# Altium schematic sheet main_scheme.SchDoc(main_scheme)
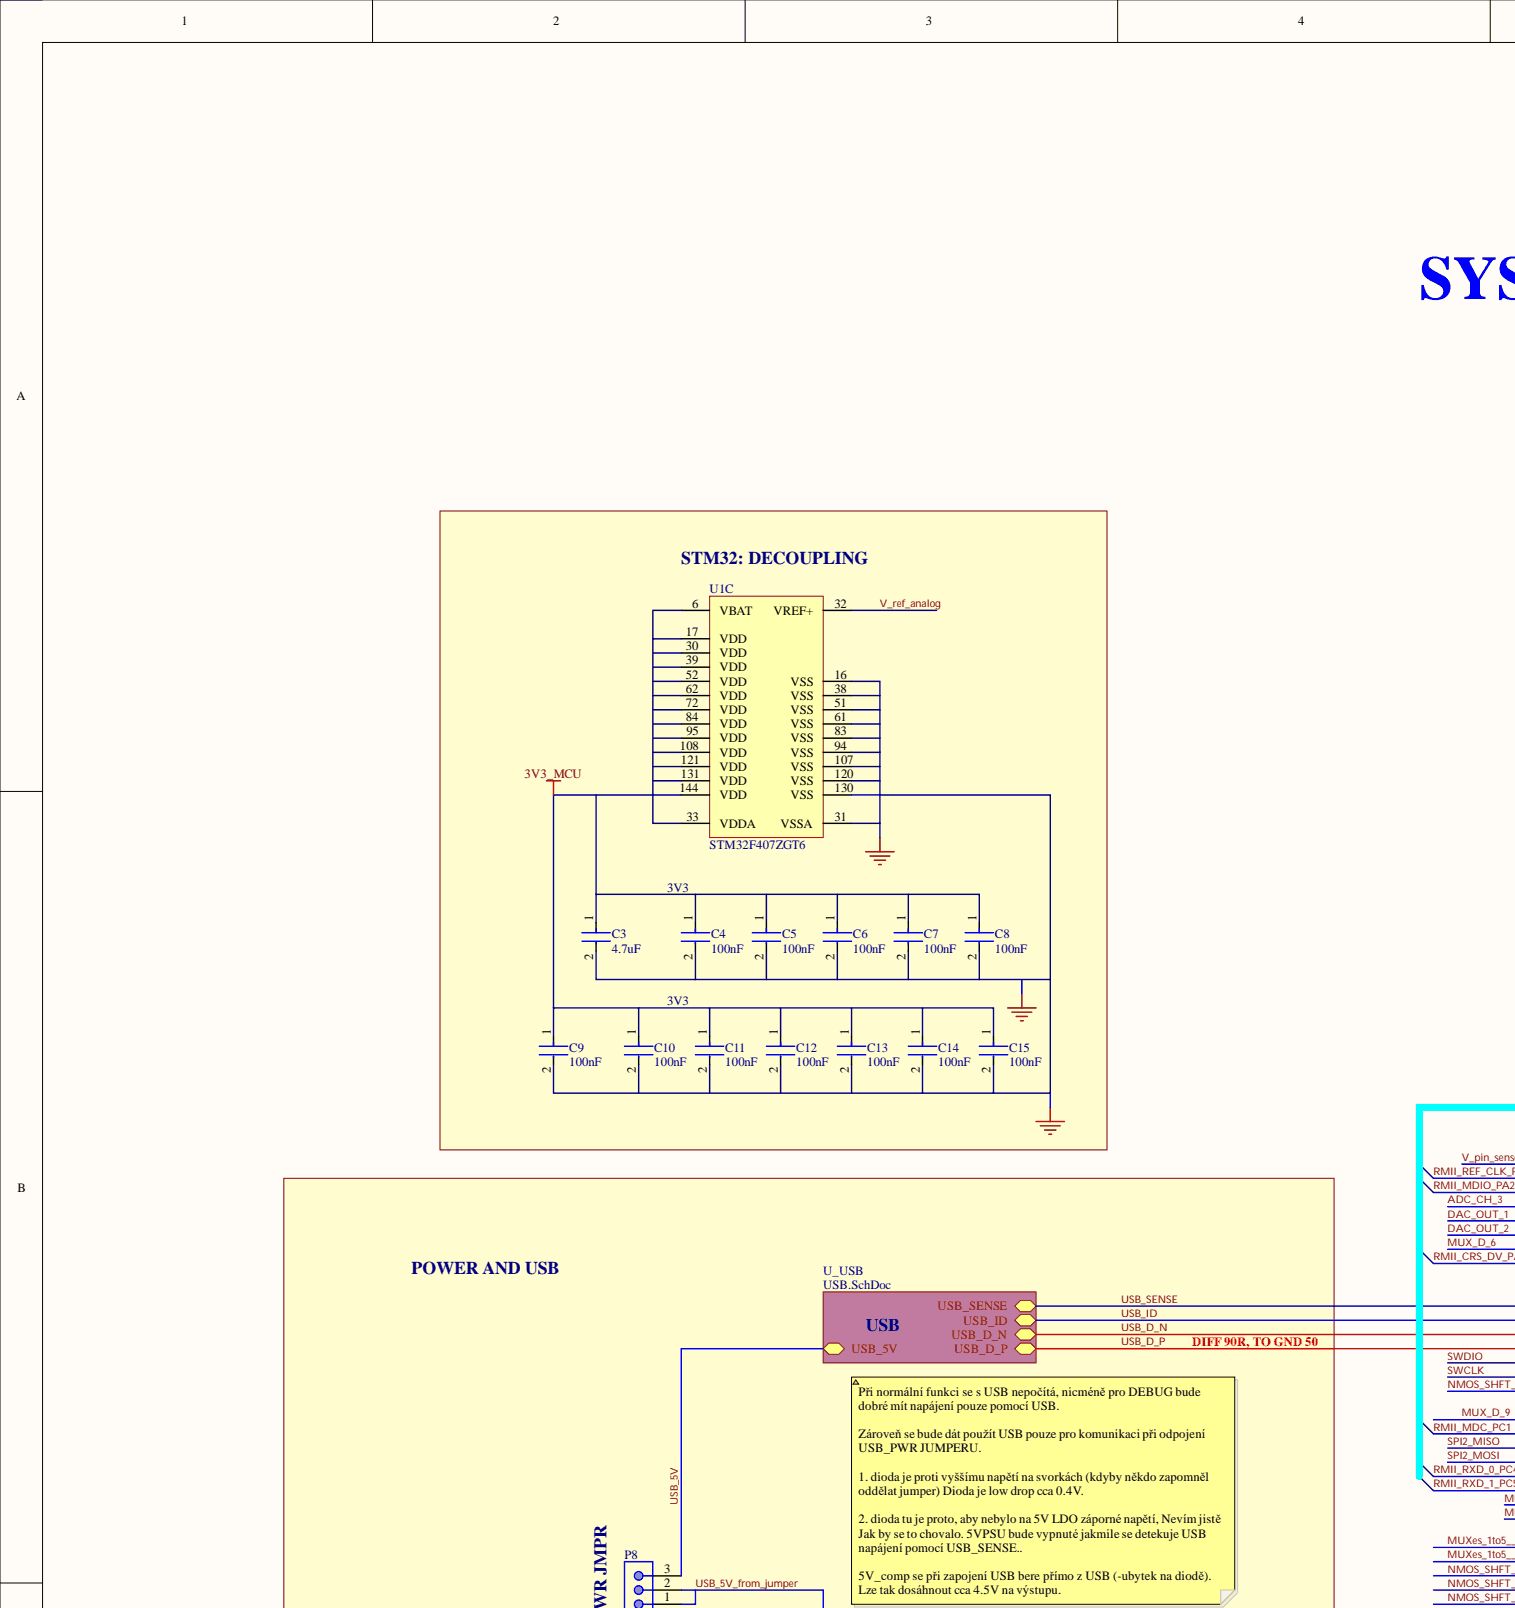
[diagram p0: main_scheme.SchDoc, top left]
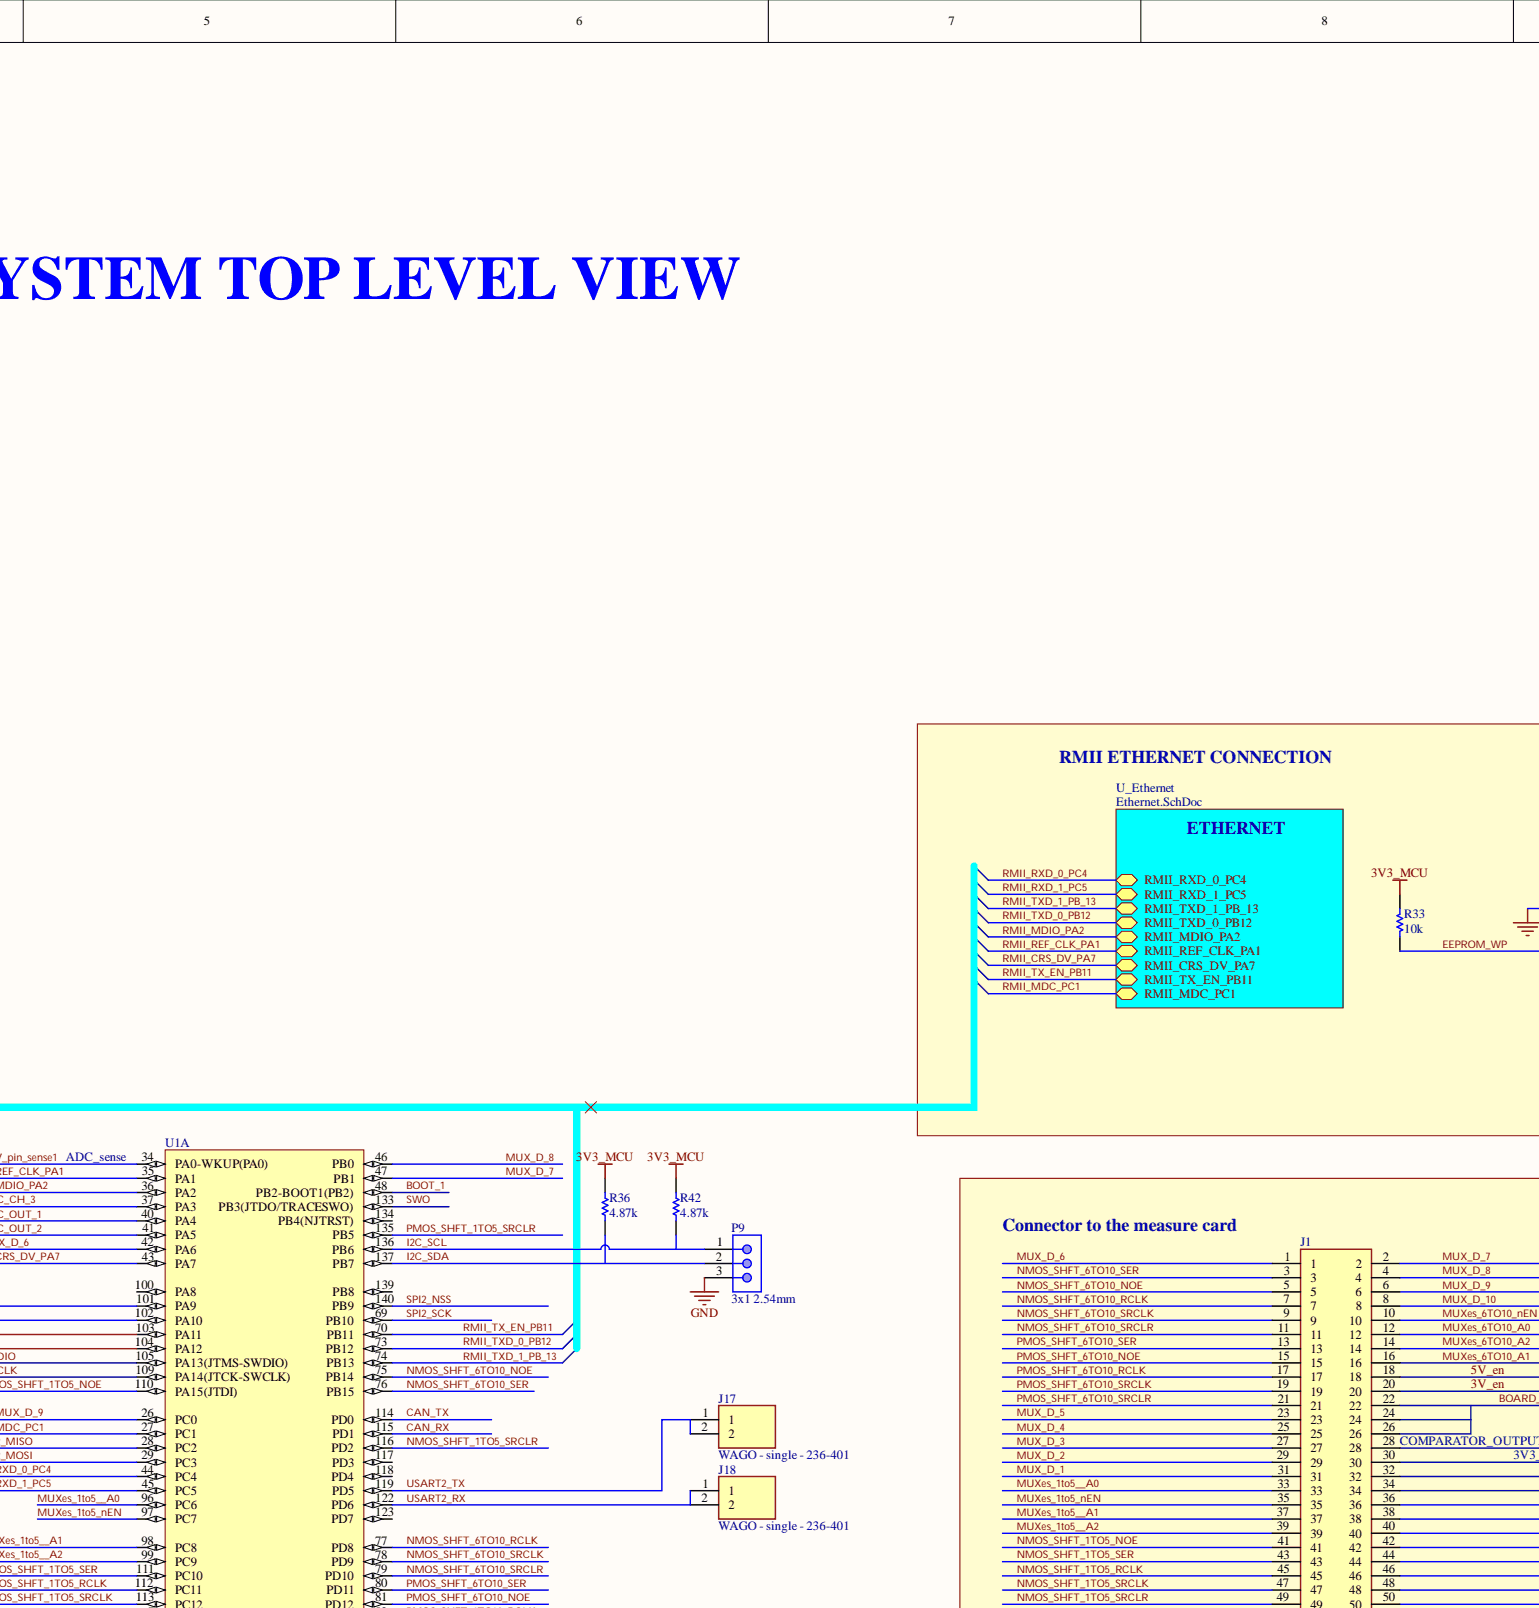
[diagram p1: main_scheme.SchDoc, top centre]
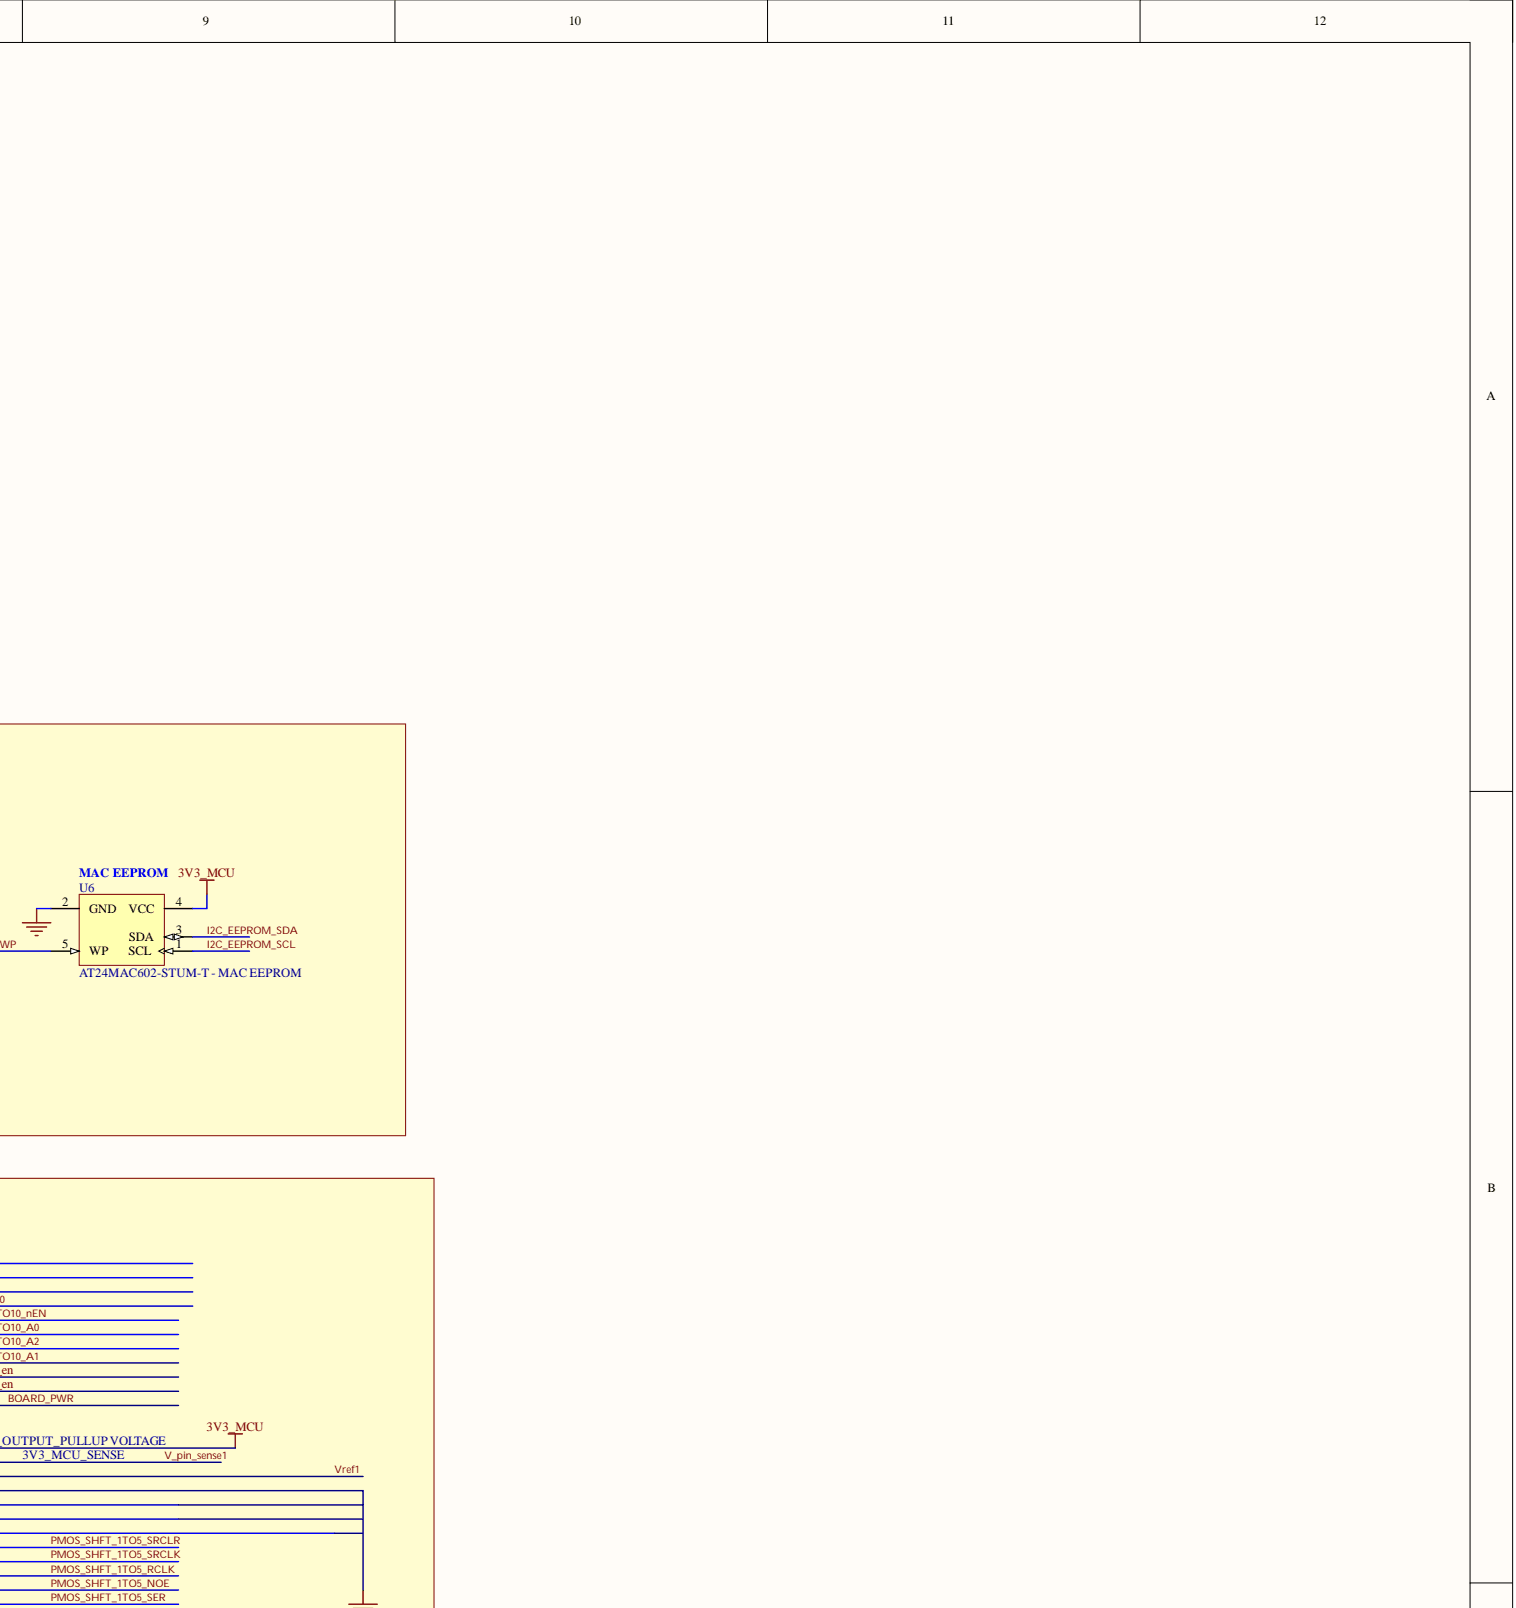
[diagram p2: main_scheme.SchDoc, top right]
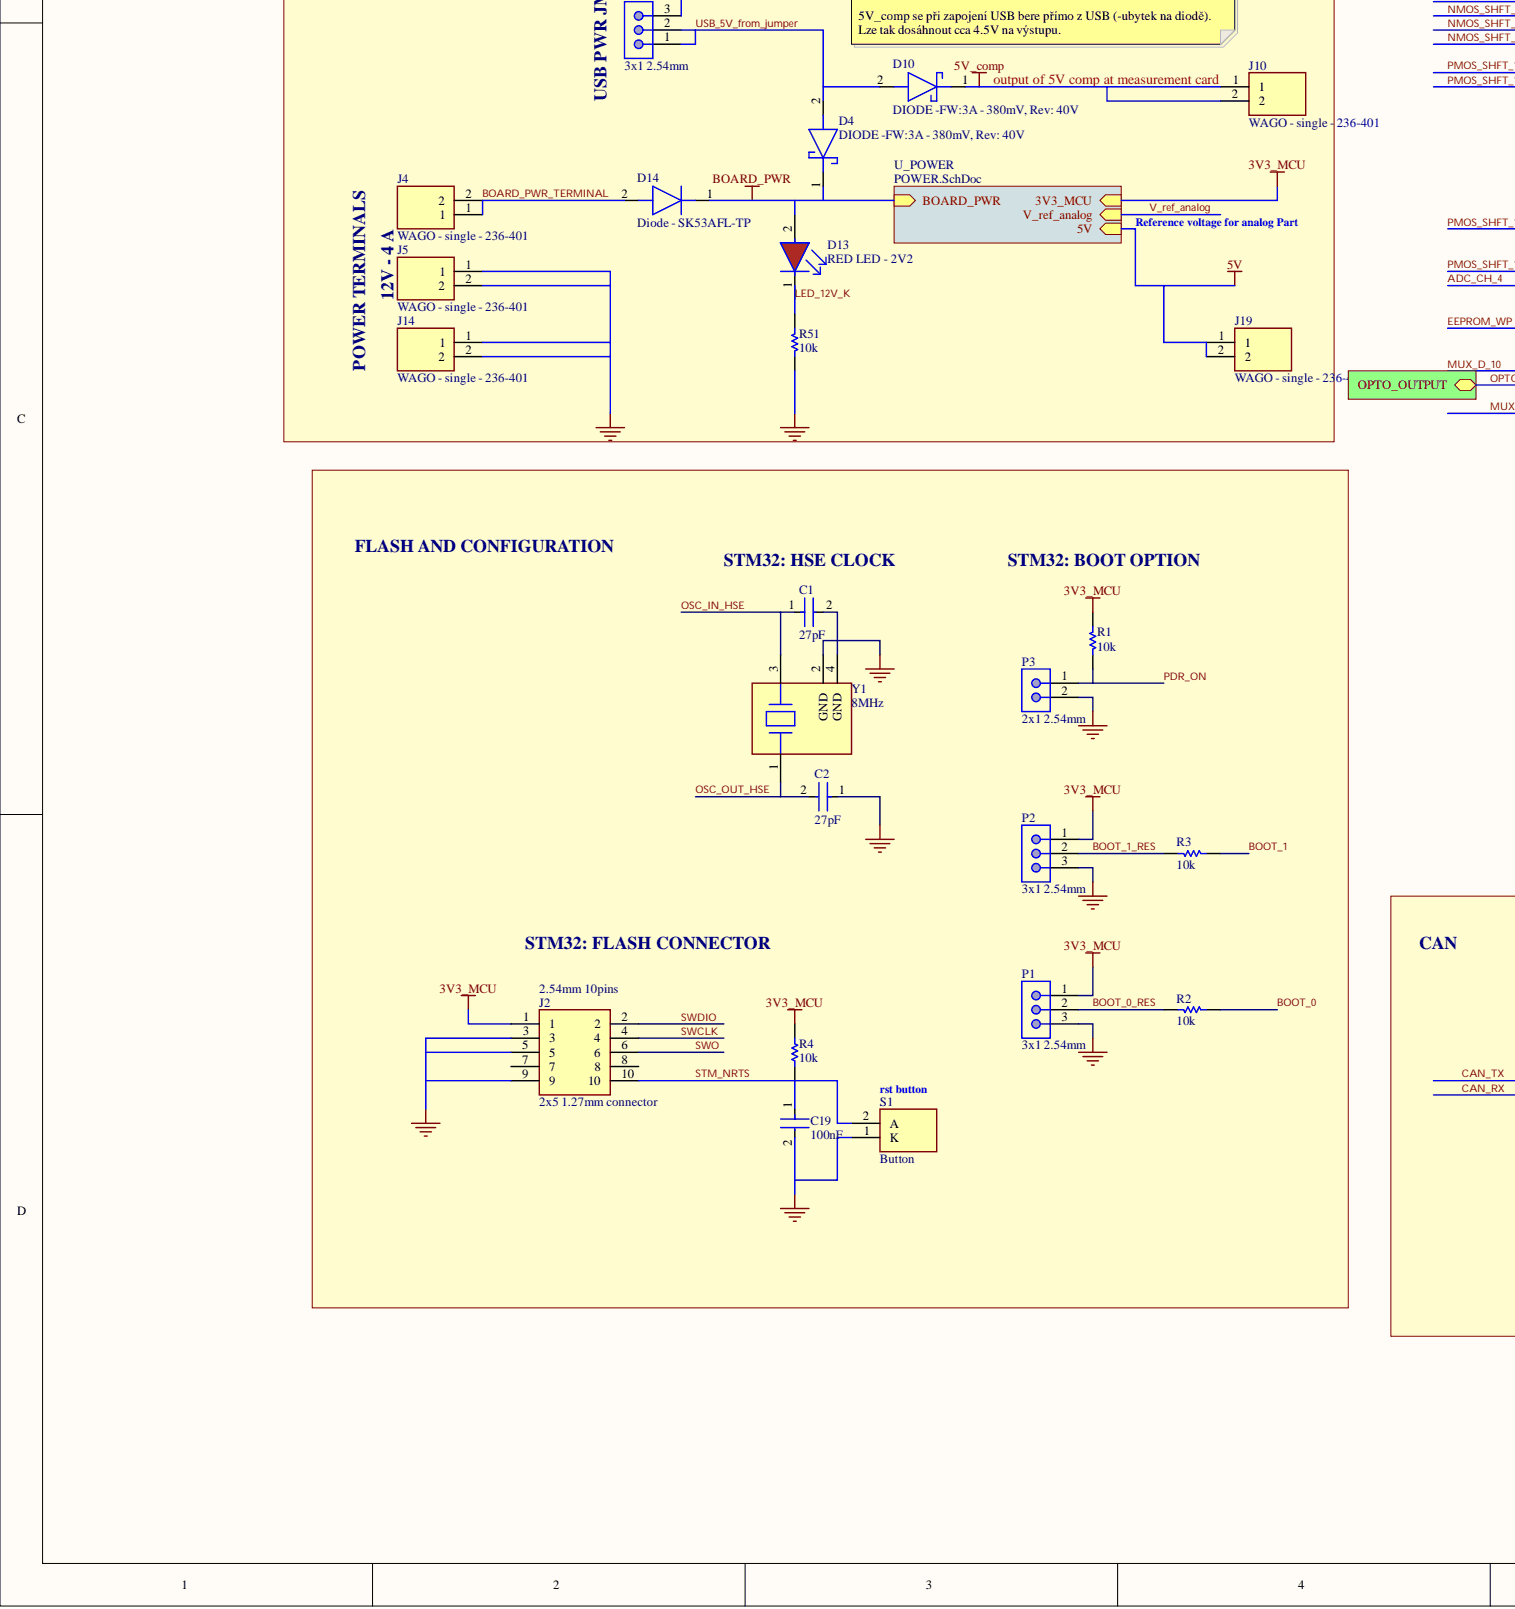
[diagram p3: main_scheme.SchDoc, bottom left]
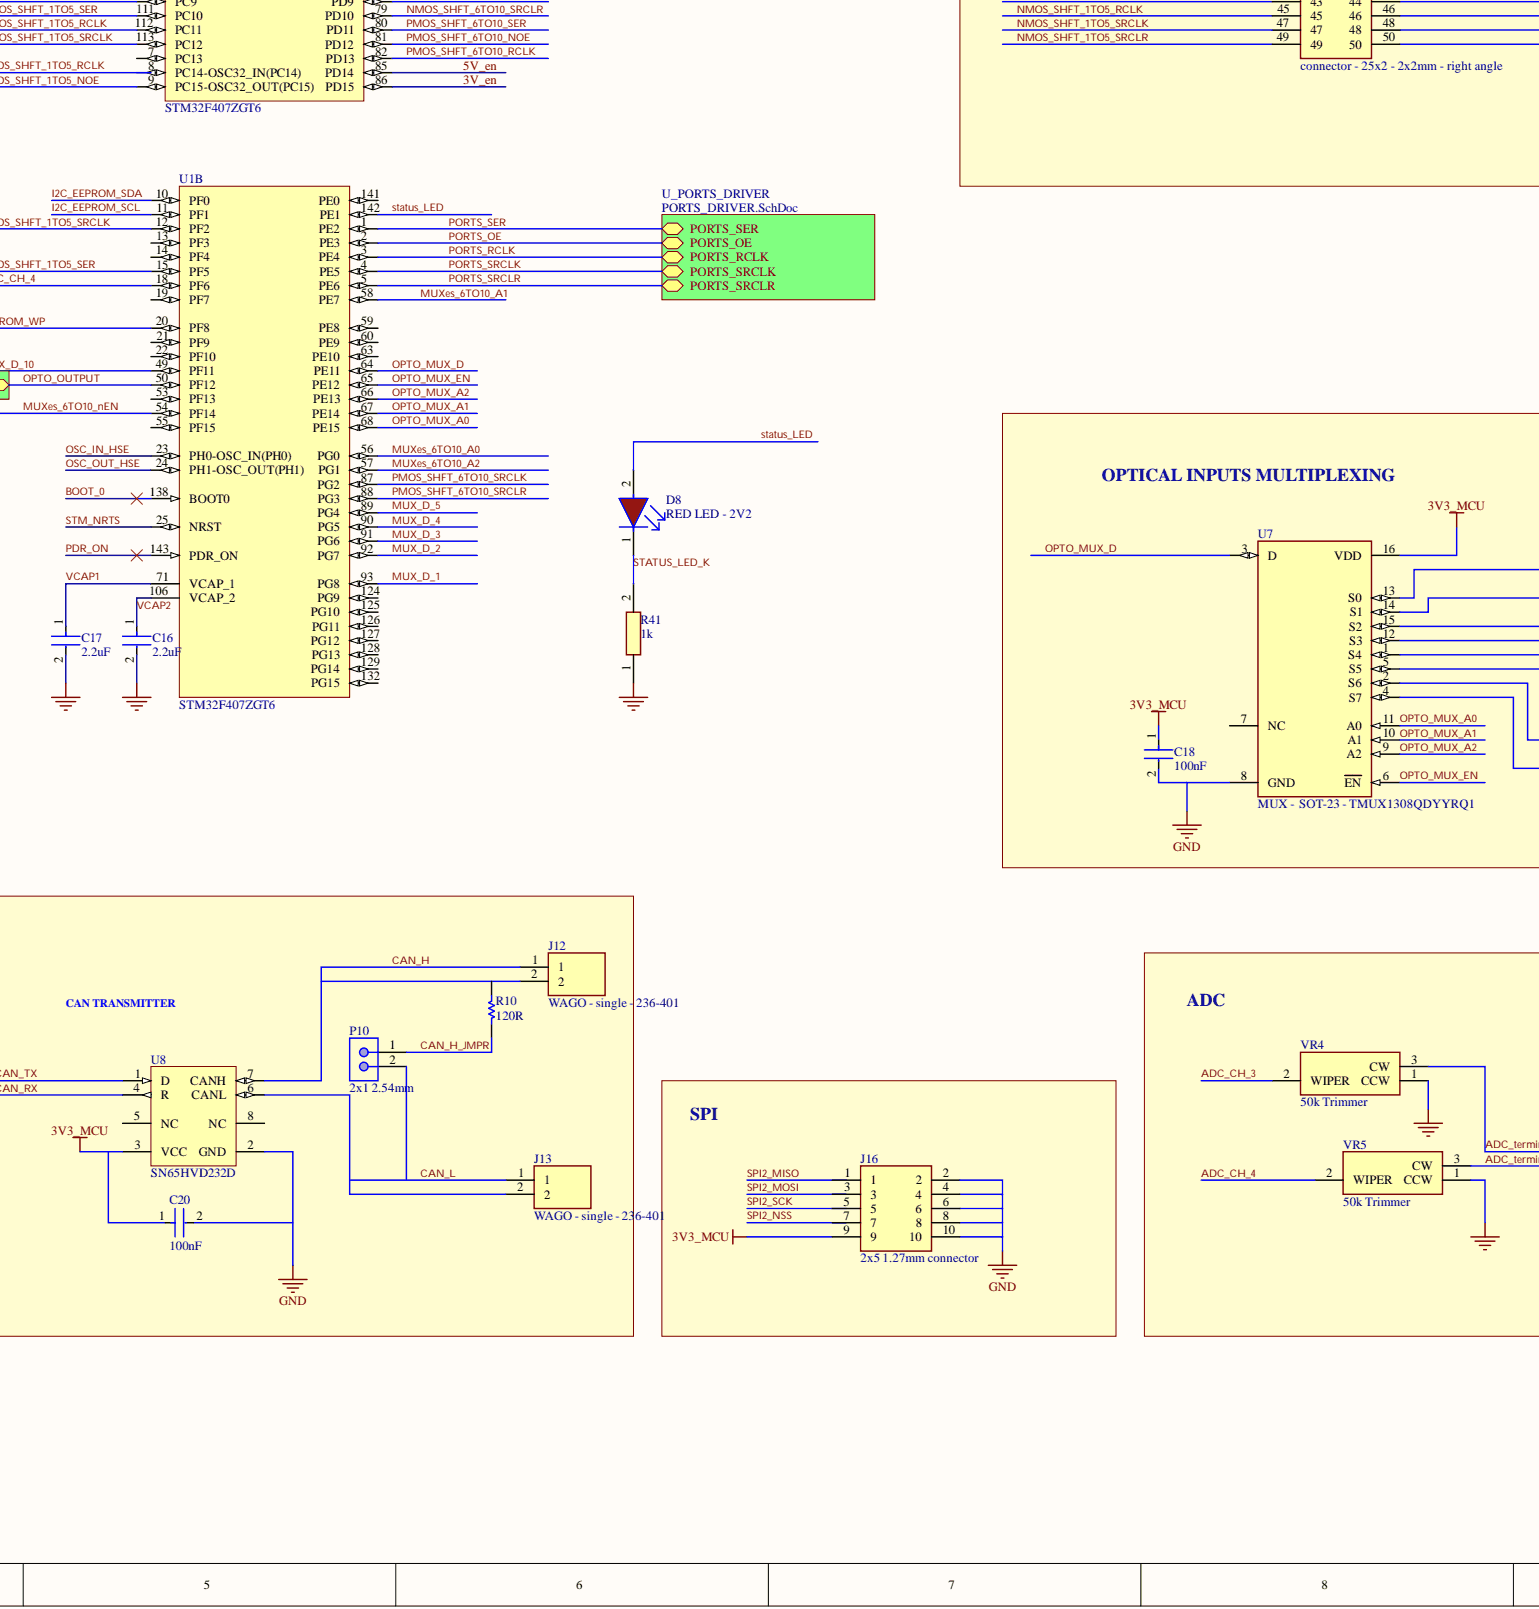
[diagram p4: main_scheme.SchDoc, bottom centre]
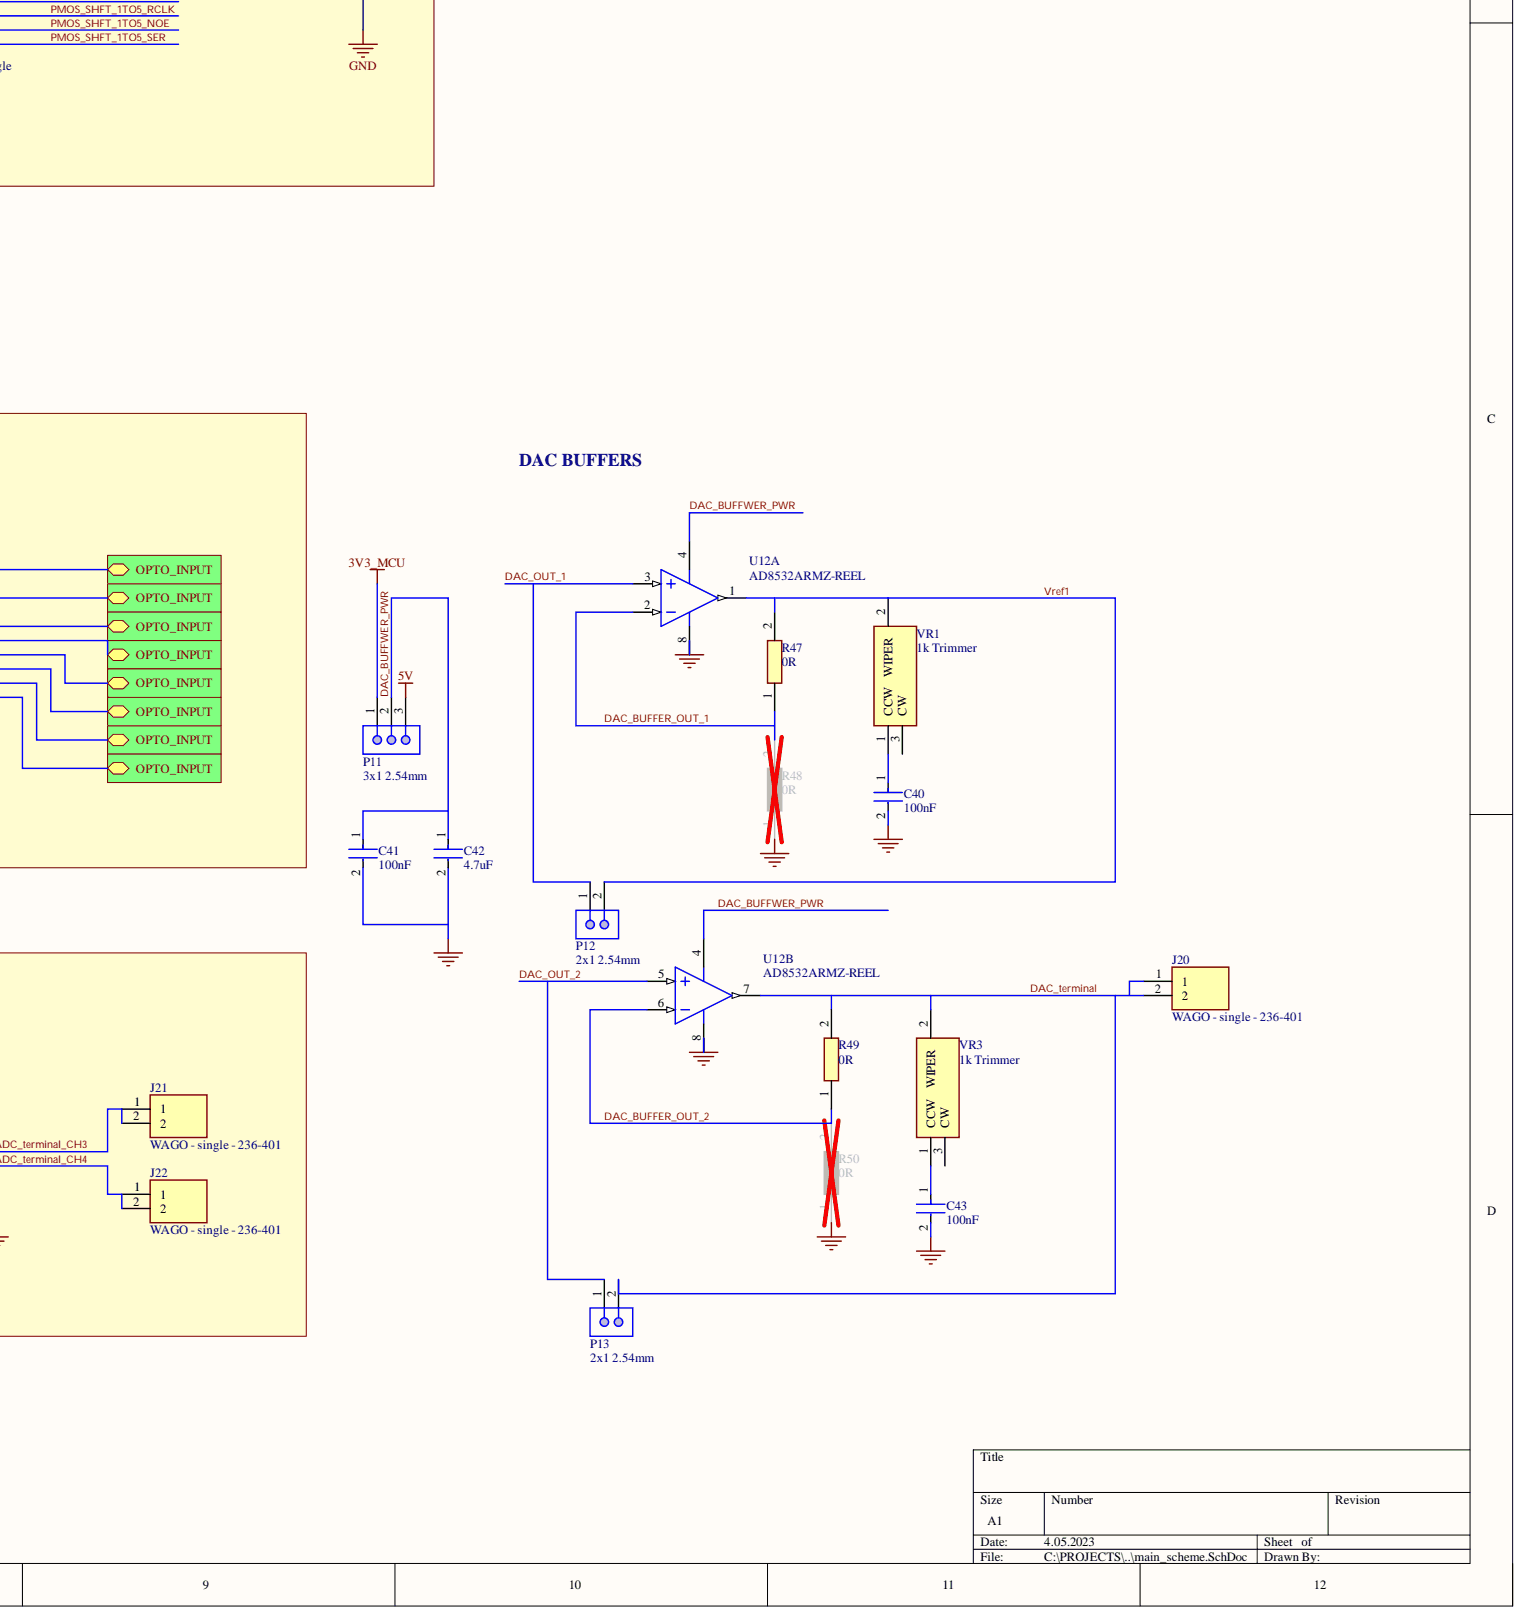
[diagram p5: main_scheme.SchDoc, bottom right]

## Parts
C1  27pF  [0402 CAP]
C2  27pF  [0402 CAP]
C3  4.7uF  [0603-CAP]
C4  100nF  [0402 CAP]
C5  100nF  [0402 CAP]
C6  100nF  [0402 CAP]
C7  100nF  [0402 CAP]
C8  100nF  [0402 CAP]
C9  100nF  [0402 CAP]
C10  100nF  [0402 CAP]
C11  100nF  [0402 CAP]
C12  100nF  [0402 CAP]
C13  100nF  [0402 CAP]
C14  100nF  [0402 CAP]
C15  100nF  [0402 CAP]
C16  2.2uF  [0603-CAP]
C17  2.2uF  [0603-CAP]
C18  100nF  [0402 CAP]
C19  100nF  [0402 CAP]
C20  100nF  [0402 CAP]
C40  100nF  [0402 CAP]
C41  100nF  [0402 CAP]
C42  4.7uF  [0603-CAP]
C43  100nF  [0402 CAP]
D4  DIODE -FW:3A - 380mV, Rev: 40V  [DIONM5127X229N]
D8  RED LED - 2V2  [0603_RED_LED]
D10  DIODE -FW:3A - 380mV, Rev: 40V  [DIONM5127X229N]
D13  RED LED - 2V2  [0603_RED_LED]
D14  Diode - SK53AFL-TP  PartNumber: SK53AFL-TP  [SODFL5026X120N]
J1  connector - 25x2 - 2x2mm - right angle  value 25x2 - 2x2mm -right angle  [SHDRRA50W55P200_2X25_5265X640X630P_RIGHT]
J2  2x5 1.27mm connector  [FTSH-105-XX-YYY-D]
J4  WAGO - single - 236-401  Package: 236-401  [WAGO-236-601]
J5  WAGO - single - 236-401  Package: 236-401  [WAGO-236-601]
J10  WAGO - single - 236-401  Package: 236-401  [WAGO-236-601]
J12  WAGO - single - 236-401  Package: 236-401  [WAGO-236-601]
J13  WAGO - single - 236-401  Package: 236-401  [WAGO-236-601]
J14  WAGO - single - 236-401  Package: 236-401  [WAGO-236-601]
J16  2x5 1.27mm connector  [FTSH-105-XX-YYY-D]
J17  WAGO - single - 236-401  Package: 236-401  [WAGO-236-601]
J18  WAGO - single - 236-401  Package: 236-401  [WAGO-236-601]
J19  WAGO - single - 236-401  Package: 236-401  [WAGO-236-601]
J20  WAGO - single - 236-401  Package: 236-401  [WAGO-236-601]
J21  WAGO - single - 236-401  Package: 236-401  [WAGO-236-601]
J22  WAGO - single - 236-401  Package: 236-401  [WAGO-236-601]
P1  3x1 2.54mm  [3x1 2.54mm header]
P2  3x1 2.54mm  [3x1 2.54mm header]
P3  2x1 2.54mm  [2.54mm header 2x1]
P8  3x1 2.54mm  [3x1 2.54mm header]
P9  3x1 2.54mm  [3x1 2.54mm header]
P10  2x1 2.54mm  [2.54mm header 2x1]
P11  3x1 2.54mm  [3x1 2.54mm header]
P12  2x1 2.54mm  [2.54mm header 2x1]
P13  2x1 2.54mm  [2.54mm header 2x1]
R1  10k  [RESC1005X40X25NL05T05]
R2  10k  [RESC1005X40X25NL05T05]
R3  10k  [RESC1005X40X25NL05T05]
R4  10k  [RESC1005X40X25NL05T05]
R10  120R  [RESC1005X40X25NL05T05]
R33  10k  [RESC1005X40X25NL05T05]
R36  4.87k  [RESC1005X40X25NL05T05]
R41  1k  [0603- SMD resistor]
R42  4.87k  [RESC1005X40X25NL05T05]
R47  0R  [0603- SMD resistor]
R48  0R  [0603- SMD resistor]
R49  0R  [0603- SMD resistor]
R50  0R  [0603- SMD resistor]
R51  10k  [RESC1005X40X25NL05T05]
S1  Button  [B3U-1000P]
U1  STM32F407ZGT6  [STM-LQFP144_L]  (parts A,B,C)
U6  AT24MAC602-STUM-T - MAC EEPROM  PartNumber: AT24MAC602-STUM-T  [ATML-5TS1_L]
U7  MUX -  SOT-23 - TMUX1308QDYYRQ1  PartNumber: TMUX1308QDYYRQ1  [FP-DYY0016A-MFG]
U8  SN65HVD232D  [D0008A_L]
U12  AD8532ARMZ-REEL  [ADI-RM-8_L]  (parts A,B)
VR1  1k Trimmer  value 1k  [TC33X2503E]
VR3  1k Trimmer  value 1k  [TC33X2503E]
VR4  50k Trimmer  value 50k  [TC33X2503E]
VR5  50k Trimmer  value 50k  [TC33X2503E]
Y1  8MHz  [4_pin_OSCILATOR]

## Nets
3V3_MCU: C3.1 C4.1 C5.1 C6.1 C7.1 C8.1 C9.1 C10.1 C11.1 C12.1 C13.1 C14.1 C15.1 C18.1 C20.1 J1.28 J2.1 J16.9 P1.1 P2.1 P11.1 R1.1 R4.1 R33.1 R36.1 R42.1 U1.6(VBAT) U1.17(VDD) U1.30(VDD) U1.33(VDDA) U1.39(VDD) U1.52(VDD) U1.62(VDD) U1.72(VDD) U1.84(VDD) U1.95(VDD) U1.108(VDD) U1.121(VDD) U1.131(VDD) U1.144(VDD) U6.4(VCC) U7.16(VDD) U8.3(VCC)
5V: J19.1 J19.2 P11.3
5V_comp: D10.1 J10.1 J10.2
GND: C1.2 C2.1 C3.2 C4.2 C5.2 C6.2 C7.2 C8.2 C9.2 C10.2 C11.2 C12.2 C13.2 C14.2 C15.2 C16.2 C17.2 C18.2 C19.2 C20.2 C40.2 C41.2 C42.2 C43.2 J1.34 J1.36 J1.38 J1.40 J2.3 J2.5 J2.9 J5.1 J5.2 J14.1 J14.2 J16.2 J16.4 J16.6 J16.8 J16.10 P1.3 P2.3 P3.2 P9.3 R41.1 R48.1 R50.1 R51.1 S1.1 U1.16(VSS) U1.31(VSSA) U1.38(VSS) U1.51(VSS) U1.61(VSS) U1.83(VSS) U1.94(VSS) U1.107(VSS) U1.120(VSS) U1.130(VSS) U6.2(GND) U7.8(GND) U8.2(GND) U12.8 VR4.1 VR5.1 Y1.2(GND) Y1.4(GND)
3V_en: J1.20 U1.86(PD15)
5V_en: J1.18 U1.85(PD14)
ADC_CH_3: U1.37(PA3) VR4.2
ADC_CH_4: U1.18(PF6) VR5.2
ADC_terminal_CH3: J21.1 J21.2 VR4.3
ADC_terminal_CH4: J22.1 J22.2 VR5.3
BOARD_PWR: D4.1 D13.2 D14.1 J1.22 J1.24 J1.26
BOARD_PWR_TERMINAL: D14.2 J4.1 J4.2
BOOT_0: R2.2 U1.138(BOOT0)
BOOT_0_RES: P1.2 R2.1
BOOT_1: R3.2 U1.48(PB2-BOOT1(PB2))
BOOT_1_RES: P2.2 R3.1
CAN_H: J12.1 J12.2 R10.2 U8.7(CANH)
CAN_H_JMPR: P10.1 R10.1
CAN_L: J13.1 J13.2 P10.2 U8.6(CANL)
CAN_RX: U1.115(PD1) U8.4(R)
CAN_TX: U1.114(PD0) U8.1(D)
DAC_BUFFER_OUT_1: R47.1 R48.2 U12.2
DAC_BUFFER_OUT_2: R49.1 R50.2 U12.6
DAC_BUFFWER_PWR: C41.1 C42.1 P11.2 U12.4
DAC_OUT_1: P12.1 U1.40(PA4) U12.3
DAC_OUT_2: P13.1 U1.41(PA5) U12.5
DAC_terminal: J20.1 J20.2 P13.2 R49.2 U12.7 VR3.2
EEPROM_WP: R33.2 U1.20(PF8) U6.5(WP)
I2C_EEPROM_SCL: U1.11(PF1) U6.1(SCL)
I2C_EEPROM_SDA: U1.10(PF0) U6.3(SDA)
I2C_SCL: P9.1 R42.2 U1.136(PB6)
I2C_SDA: P9.2 R36.2 U1.137(PB7)
LED_12V_K: D13.1 R51.2
MUX_D_1: J1.31 U1.93(PG8)
MUX_D_2: J1.29 U1.92(PG7)
MUX_D_3: J1.27 U1.91(PG6)
MUX_D_4: J1.25 U1.90(PG5)
MUX_D_5: J1.23 U1.89(PG4)
MUX_D_6: J1.1 U1.42(PA6)
MUX_D_7: J1.2 U1.47(PB1)
MUX_D_8: J1.4 U1.46(PB0)
MUX_D_9: J1.6 U1.26(PC0)
MUX_D_10: J1.8 U1.49(PF11)
MUXes_1to5__A0: J1.33 U1.96(PC6)
MUXes_1to5__A1: J1.37 U1.98(PC8)
MUXes_1to5__A2: J1.39 U1.99(PC9)
MUXes_1to5_nEN: J1.35 U1.97(PC7)
MUXes_6TO10_A0: J1.12 U1.56(PG0)
MUXes_6TO10_A1: J1.16 U1.58(PE7)
MUXes_6TO10_A2: J1.14 U1.57(PG1)
MUXes_6TO10_nEN: J1.10 U1.54(PF14)
NMOS_SHFT_1TO5_NOE: J1.41 U1.110(PA15(JTDI))
NMOS_SHFT_1TO5_RCLK: J1.45 U1.112(PC11)
NMOS_SHFT_1TO5_SER: J1.43 U1.111(PC10)
NMOS_SHFT_1TO5_SRCLK: J1.47 U1.113(PC12)
NMOS_SHFT_1TO5_SRCLR: J1.49 U1.116(PD2)
NMOS_SHFT_6TO10_NOE: J1.5 U1.75(PB14)
NMOS_SHFT_6TO10_RCLK: J1.7 U1.77(PD8)
NMOS_SHFT_6TO10_SER: J1.3 U1.76(PB15)
NMOS_SHFT_6TO10_SRCLK: J1.9 U1.78(PD9)
NMOS_SHFT_6TO10_SRCLR: J1.11 U1.79(PD10)
OPTO_MUX_A0: U1.68(PE15) U7.11(A0)
OPTO_MUX_A1: U1.67(PE14) U7.10(A1)
OPTO_MUX_A2: U1.66(PE13) U7.9(A2)
OPTO_MUX_D: U1.64(PE11) U7.3(D)
OPTO_MUX_EN: U1.65(PE12) U7.6(EN)
OPTO_OUTPUT: U1.50(PF12)
OSC_IN_HSE: C1.1 U1.23(PH0-OSC_IN(PH0)) Y1.3
OSC_OUT_HSE: C2.2 U1.24(PH1-OSC_OUT(PH1)) Y1.1
PDR_ON: P3.1 R1.2 U1.143(PDR_ON)
PMOS_SHFT_1TO5_NOE: J1.48 U1.9(PC15-OSC32_OUT(PC15))
PMOS_SHFT_1TO5_RCLK: J1.46 U1.8(PC14-OSC32_IN(PC14))
PMOS_SHFT_1TO5_SER: J1.50 U1.15(PF5)
PMOS_SHFT_1TO5_SRCLK: J1.44 U1.12(PF2)
PMOS_SHFT_1TO5_SRCLR: J1.42 U1.135(PB5)
PMOS_SHFT_6TO10_NOE: J1.15 U1.81(PD12)
PMOS_SHFT_6TO10_RCLK: J1.17 U1.82(PD13)
PMOS_SHFT_6TO10_SER: J1.13 U1.80(PD11)
PMOS_SHFT_6TO10_SRCLK: J1.19 U1.87(PG2)
PMOS_SHFT_6TO10_SRCLR: J1.21 U1.88(PG3)
PORTS_OE: U1.2(PE3)
PORTS_RCLK: U1.3(PE4)
PORTS_SER: U1.1(PE2)
PORTS_SRCLK: U1.4(PE5)
PORTS_SRCLR: U1.5(PE6)
RMII_CRS_DV_PA7: U1.43(PA7)
RMII_MDC_PC1: U1.27(PC1)
RMII_MDIO_PA2: U1.36(PA2)
RMII_REF_CLK_PA1: U1.35(PA1)
RMII_RXD_0_PC4: U1.44(PC4)
RMII_RXD_1_PC5: U1.45(PC5)
RMII_TX_EN_PB11: U1.70(PB11)
RMII_TXD_0_PB12: U1.73(PB12)
RMII_TXD_1_PB_13: U1.74(PB13)
SPI2_MISO: J16.1 U1.28(PC2)
SPI2_MOSI: J16.3 U1.29(PC3)
SPI2_NSS: J16.7 U1.140(PB9)
SPI2_SCK: J16.5 U1.69(PB10)
status_LED: D8.2 U1.142(PE1)
STATUS_LED_K: D8.1 R41.2
STM_NRTS: C19.1 J2.10 R4.2 S1.2 U1.25(NRST)
SWCLK: J2.4 U1.109(PA14(JTCK-SWCLK))
SWDIO: J2.2 U1.105(PA13(JTMS-SWDIO))
SWO: J2.6 U1.133(PB3(JTDO/TRACESWO))
USART2_RX: J18.1 J18.2 U1.122(PD6)
USART2_TX: J17.1 J17.2 U1.119(PD5)
USB_5V: P8.3
USB_5V_from_jumper: D4.2 D10.2 P8.1 P8.2
USB_D_N: U1.103(PA11)
USB_D_P: U1.104(PA12)
USB_ID: U1.102(PA10)
USB_SENSE: U1.101(PA9)
V_pin_sense1: J1.30 U1.34(PA0-WKUP(PA0))
V_ref_analog: U1.32(VREF+)
VCAP1: C17.1 U1.71(VCAP_1)
VCAP2: C16.1 U1.106(VCAP_2)
Vref1: J1.32 P12.2 R47.2 U12.1 VR1.2
NetC40_1: C40.1 VR1.1
NetC43_1: C43.1 VR3.1
NetJ2_7: J2.7
NetJ2_8: J2.8
NetU1_7: U1.7(PC13)
NetU1_13: U1.13(PF3)
NetU1_14: U1.14(PF4)
NetU1_19: U1.19(PF7)
NetU1_21: U1.21(PF9)
NetU1_22: U1.22(PF10)
NetU1_53: U1.53(PF13)
NetU1_55: U1.55(PF15)
NetU1_59: U1.59(PE8)
NetU1_60: U1.60(PE9)
NetU1_63: U1.63(PE10)
NetU1_100: U1.100(PA8)
NetU1_117: U1.117(PD3)
NetU1_118: U1.118(PD4)
NetU1_123: U1.123(PD7)
NetU1_124: U1.124(PG9)
NetU1_125: U1.125(PG10)
NetU1_126: U1.126(PG11)
NetU1_127: U1.127(PG12)
NetU1_128: U1.128(PG13)
NetU1_129: U1.129(PG14)
NetU1_132: U1.132(PG15)
NetU1_134: U1.134(PB4(NJTRST))
NetU1_139: U1.139(PB8)
NetU1_141: U1.141(PE0)
NetU7_1: U7.1(S4)
NetU7_2: U7.2(S6)
NetU7_4: U7.4(S7)
NetU7_5: U7.5(S5)
NetU7_7: U7.7(NC)
NetU7_12: U7.12(S3)
NetU7_13: U7.13(S0)
NetU7_14: U7.14(S1)
NetU7_15: U7.15(S2)
NetU8_5: U8.5(NC)
NetU8_8: U8.8(NC)
NetVR1_3: VR1.3
NetVR3_3: VR3.3
